# Altium schematic sheet ActuatorL.SchDoc(ActuatorL)
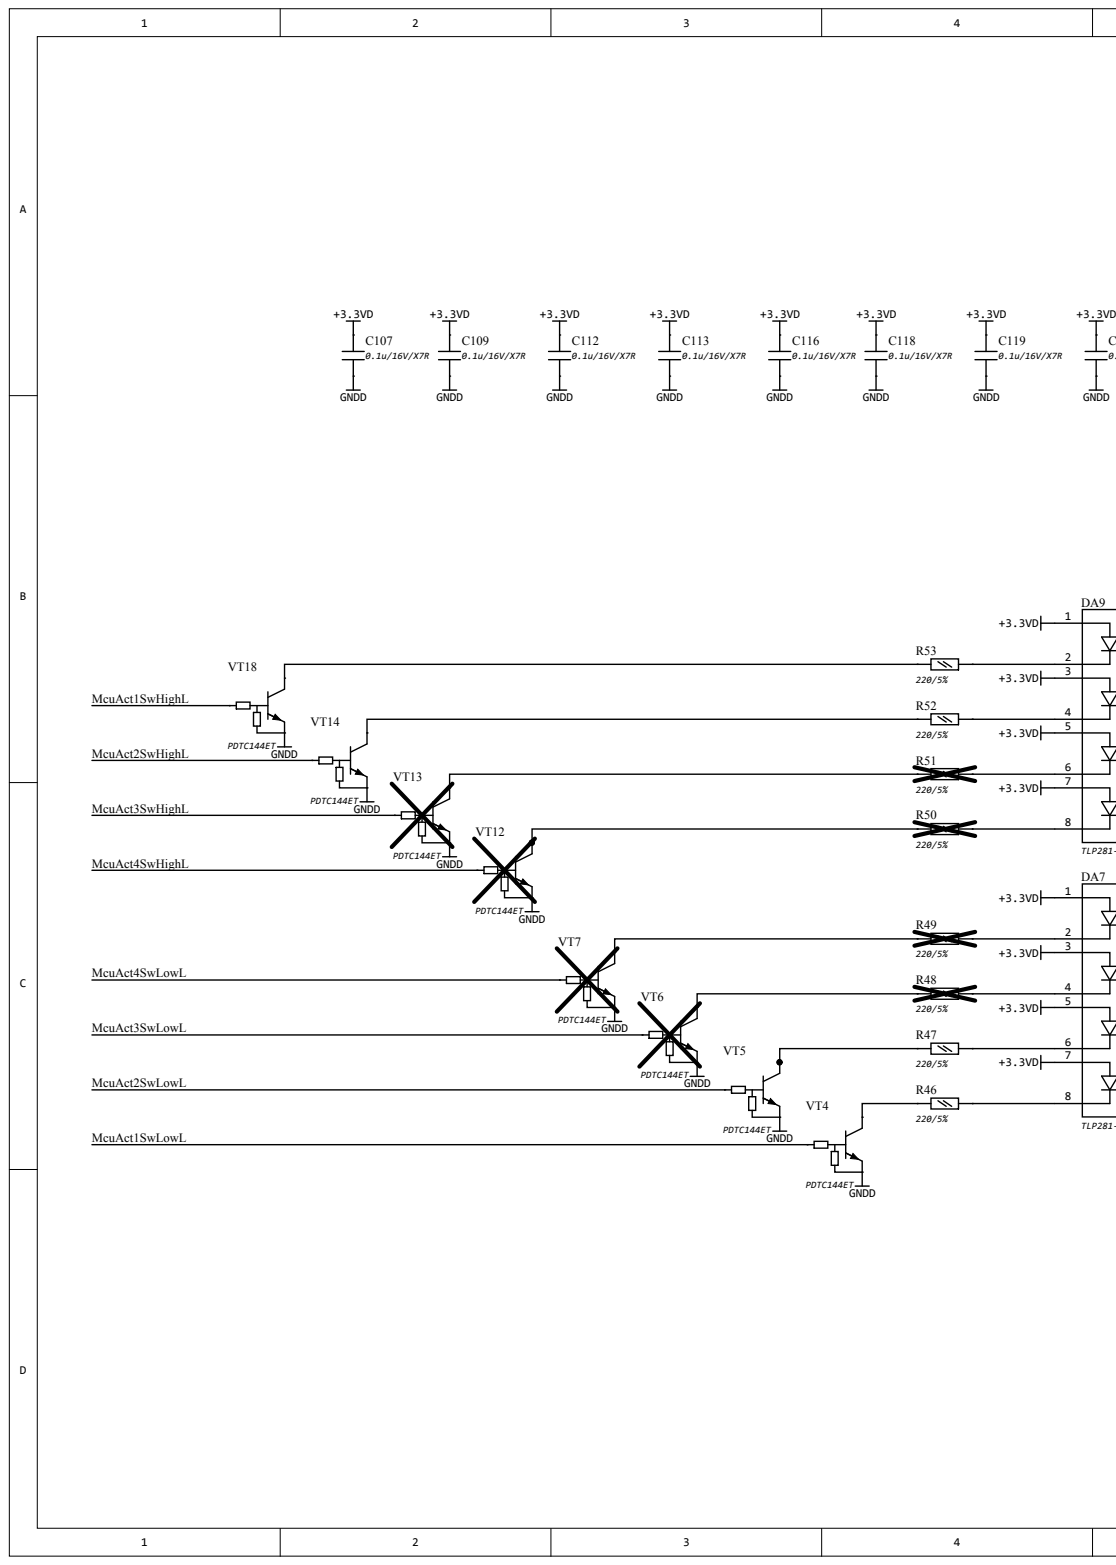
[diagram p0: ActuatorL.SchDoc, left]
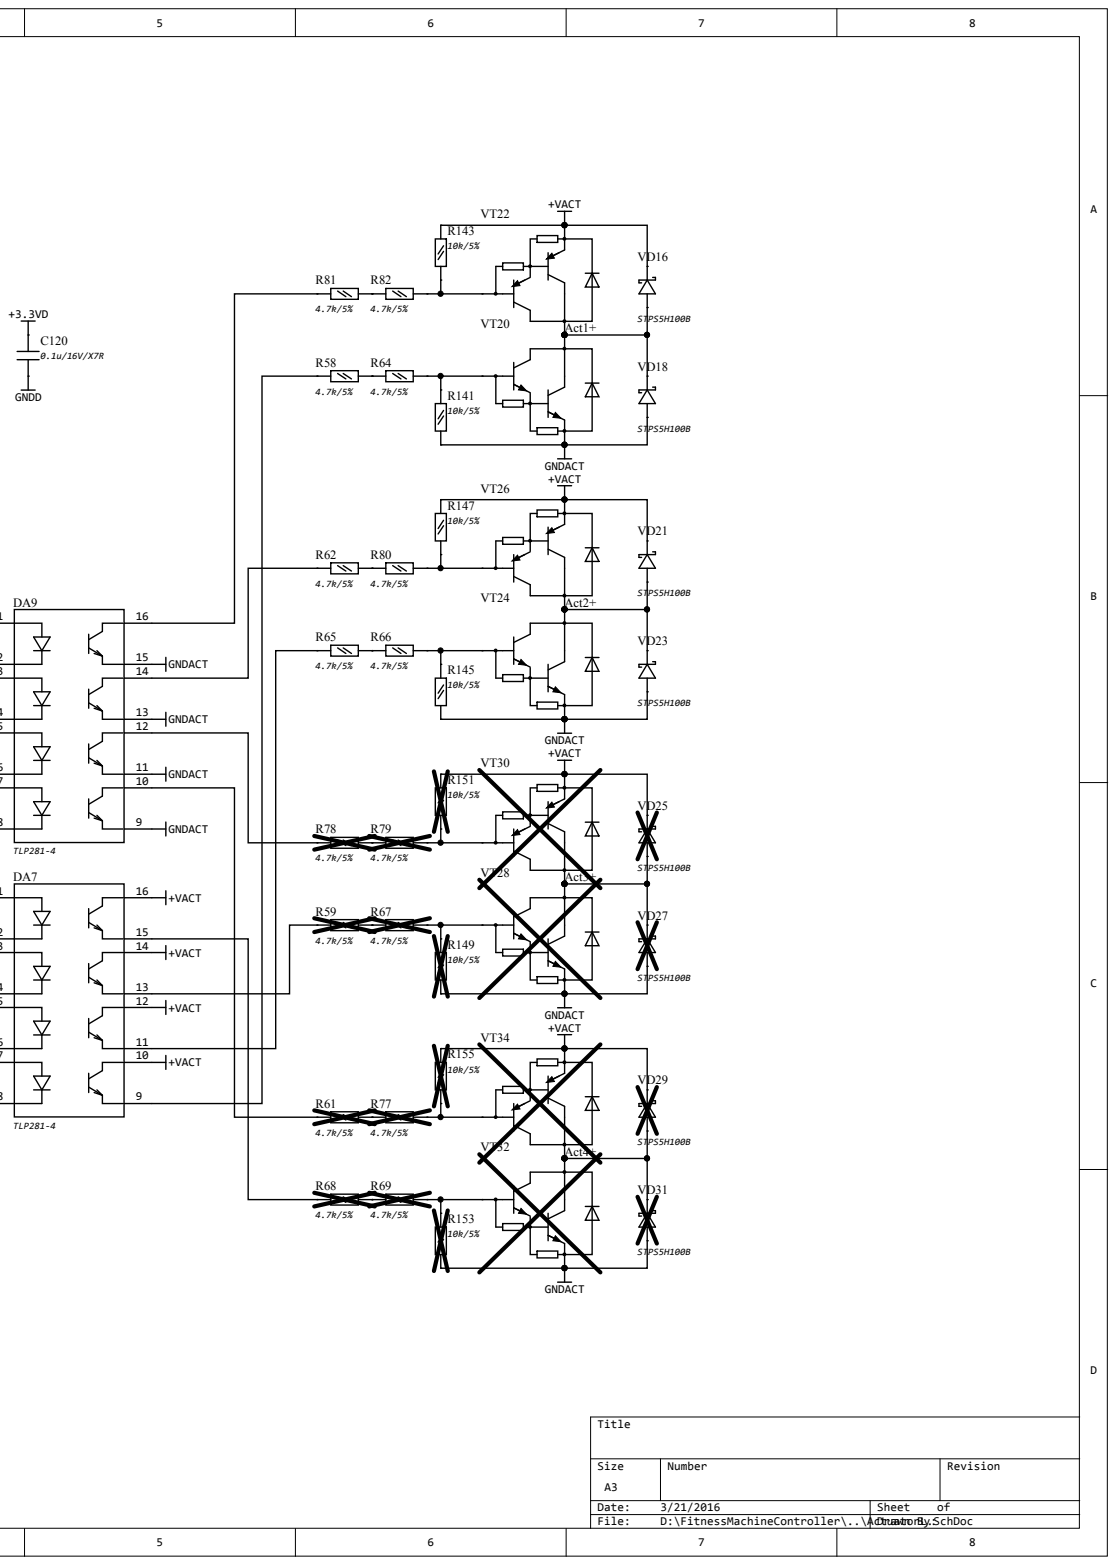
[diagram p1: ActuatorL.SchDoc, right]

## Parts
C107  0.1u/16V/X7R
C109  0.1u/16V/X7R
C112  0.1u/16V/X7R
C113  0.1u/16V/X7R
C116  0.1u/16V/X7R
C118  0.1u/16V/X7R
C119  0.1u/16V/X7R
C120  0.1u/16V/X7R
DA7  TLP281-4
DA9  TLP281-4
R46  220/5%
R47  220/5%
R48  220/5%
R49  220/5%
R50  220/5%
R51  220/5%
R52  220/5%
R53  220/5%
R58  4.7k/5%
R59  4.7k/5%
R61  4.7k/5%
R62  4.7k/5%
R64  4.7k/5%
R65  4.7k/5%
R66  4.7k/5%
R67  4.7k/5%
R68  4.7k/5%
R69  4.7k/5%
R77  4.7k/5%
R78  4.7k/5%
R79  4.7k/5%
R80  4.7k/5%
R81  4.7k/5%
R82  4.7k/5%
R141  10k/5%
R143  10k/5%
R145  10k/5%
R147  10k/5%
R149  10k/5%
R151  10k/5%
R153  10k/5%
R155  10k/5%
VD16  STPS5H100B
VD18  STPS5H100B
VD21  STPS5H100B
VD23  STPS5H100B
VD25  STPS5H100B
VD27  STPS5H100B
VD29  STPS5H100B
VD31  STPS5H100B
VT4  PDTC144ET
VT5  PDTC144ET
VT6  PDTC144ET
VT7  PDTC144ET
VT12  PDTC144ET
VT13  PDTC144ET
VT14  PDTC144ET
VT18  PDTC144ET
VT20  BJT_NPN_DARLINGTON_TO220  (value not drawn)
VT22  BJT_PNP_DARLINGTON_TO220  (value not drawn)
VT24  BJT_NPN_DARLINGTON_TO220  (value not drawn)
VT26  BJT_PNP_DARLINGTON_TO220  (value not drawn)
VT28  BJT_NPN_DARLINGTON_TO220  (value not drawn)
VT30  BJT_PNP_DARLINGTON_TO220  (value not drawn)
VT32  BJT_NPN_DARLINGTON_TO220  (value not drawn)
VT34  BJT_PNP_DARLINGTON_TO220  (value not drawn)

## Nets
+3.3VD: C107.2 C109.2 C112.2 C113.2 C116.2 C118.2 C119.2 C120.2 DA7.1 DA7.3 DA7.5 DA7.7 DA9.1 DA9.3 DA9.5 DA9.7
+VACT: DA7.10 DA7.12 DA7.14 DA7.16 R143.2 R147.2 R151.2 R155.2 VD16.3 VD21.3 VD25.3 VD29.3 VT22.3 VT26.3 VT30.3 VT34.3
GNDACT: DA9.9 DA9.11 DA9.13 DA9.15 R141.1 R145.1 R149.1 R153.1 VD18.2 VD23.2 VD27.2 VD31.2 VT20.3 VT24.3 VT28.3 VT32.3
GNDD: C107.1 C109.1 C112.1 C113.1 C116.1 C118.1 C119.1 C120.1 VT4.2 VT5.2 VT6.2 VT7.2 VT12.2 VT13.2 VT14.2 VT18.2
ACT1+: VD16.2 VD18.3 VT20.2 VT22.2
ACT2+: VD21.2 VD23.3 VT24.2 VT26.2
ACT3+: VD25.2 VD27.3 VT28.2 VT30.2
ACT4+: VD29.2 VD31.3 VT32.2 VT34.2
MCUACT1SWHIGHL: VT18.1
MCUACT1SWLOWL: VT4.1
MCUACT2SWHIGHL: VT14.1
MCUACT2SWLOWL: VT5.1
MCUACT3SWHIGHL: VT13.1
MCUACT3SWLOWL: VT6.1
MCUACT4SWHIGHL: VT12.1
MCUACT4SWLOWL: VT7.1
NetDA7_2: DA7.2 R49.2
NetDA7_4: DA7.4 R48.2
NetDA7_6: DA7.6 R47.2
NetDA7_8: DA7.8 R46.2
NetDA7_9: DA7.9 R58.2
NetDA7_11: DA7.11 R65.2
NetDA7_13: DA7.13 R59.2
NetDA7_15: DA7.15 R68.2
NetDA9_2: DA9.2 R53.2
NetDA9_4: DA9.4 R52.2
NetDA9_6: DA9.6 R51.2
NetDA9_8: DA9.8 R50.2
NetDA9_10: DA9.10 R61.2
NetDA9_12: DA9.12 R78.2
NetDA9_14: DA9.14 R62.2
NetDA9_16: DA9.16 R81.2
NetR46_1: R46.1 VT4.3
NetR47_1: R47.1 VT5.3
NetR48_1: R48.1 VT6.3
NetR49_1: R49.1 VT7.3
NetR50_1: R50.1 VT12.3
NetR51_1: R51.1 VT13.3
NetR52_1: R52.1 VT14.3
NetR53_1: R53.1 VT18.3
NetR58_1: R58.1 R64.2
NetR59_1: R59.1 R67.2
NetR61_1: R61.1 R77.2
NetR62_1: R62.1 R80.2
NetR64_1: R64.1 R141.2 VT20.1
NetR65_1: R65.1 R66.2
NetR66_1: R66.1 R145.2 VT24.1
NetR67_1: R67.1 R149.2 VT28.1
NetR68_1: R68.1 R69.2
NetR69_1: R69.1 R153.2 VT32.1
NetR77_1: R77.1 R155.1 VT34.1
NetR78_1: R78.1 R79.2
NetR79_1: R79.1 R151.1 VT30.1
NetR80_1: R80.1 R147.1 VT26.1
NetR81_1: R81.1 R82.2
NetR82_1: R82.1 R143.1 VT22.1

## Library data of the parts
C107 C109 C112 C113 C116 C118-C120 = (no symbol name): ComponentDescriptionRUS: Конденсатор SMD 0603; Analog: нет; NumberSolderPointsSMD: 0; NumberSolderPointsTHT: 0
DA7 DA9 = (no symbol name): ComponentDescriptionRUS: Оптрон; Аналог: TLP291-4
R46-R53 R58 R59 R61 R62 R64-R69 R77-R82 R141 R143 R145 R147 R149 R151 R153 R155 = (no symbol name): ComponentDescriptionRUS: Резистор SMD 0603; Analog: нет; NumberSolderPointsSMD: 0; NumberSolderPointsTHT: 0
VD16 VD18 VD21 VD23 VD25 VD27 VD29 VD31 VT22 VT26 VT30 VT34 = (no symbol name): Analog: нет; NumberSolderPointsSMD: 0; NumberSolderPointsTHT: 0
VT4-VT7 VT12-VT14 VT18 VT20 VT24 VT28 VT32 = (no symbol name): ComponentDescriptionRUS: Транзистор SOT23; Analog: нет; NumberSolderPointsSMD: 0; NumberSolderPointsTHT: 0
